# Altium schematic sheet Master_Rev4_½AA.SchDoc
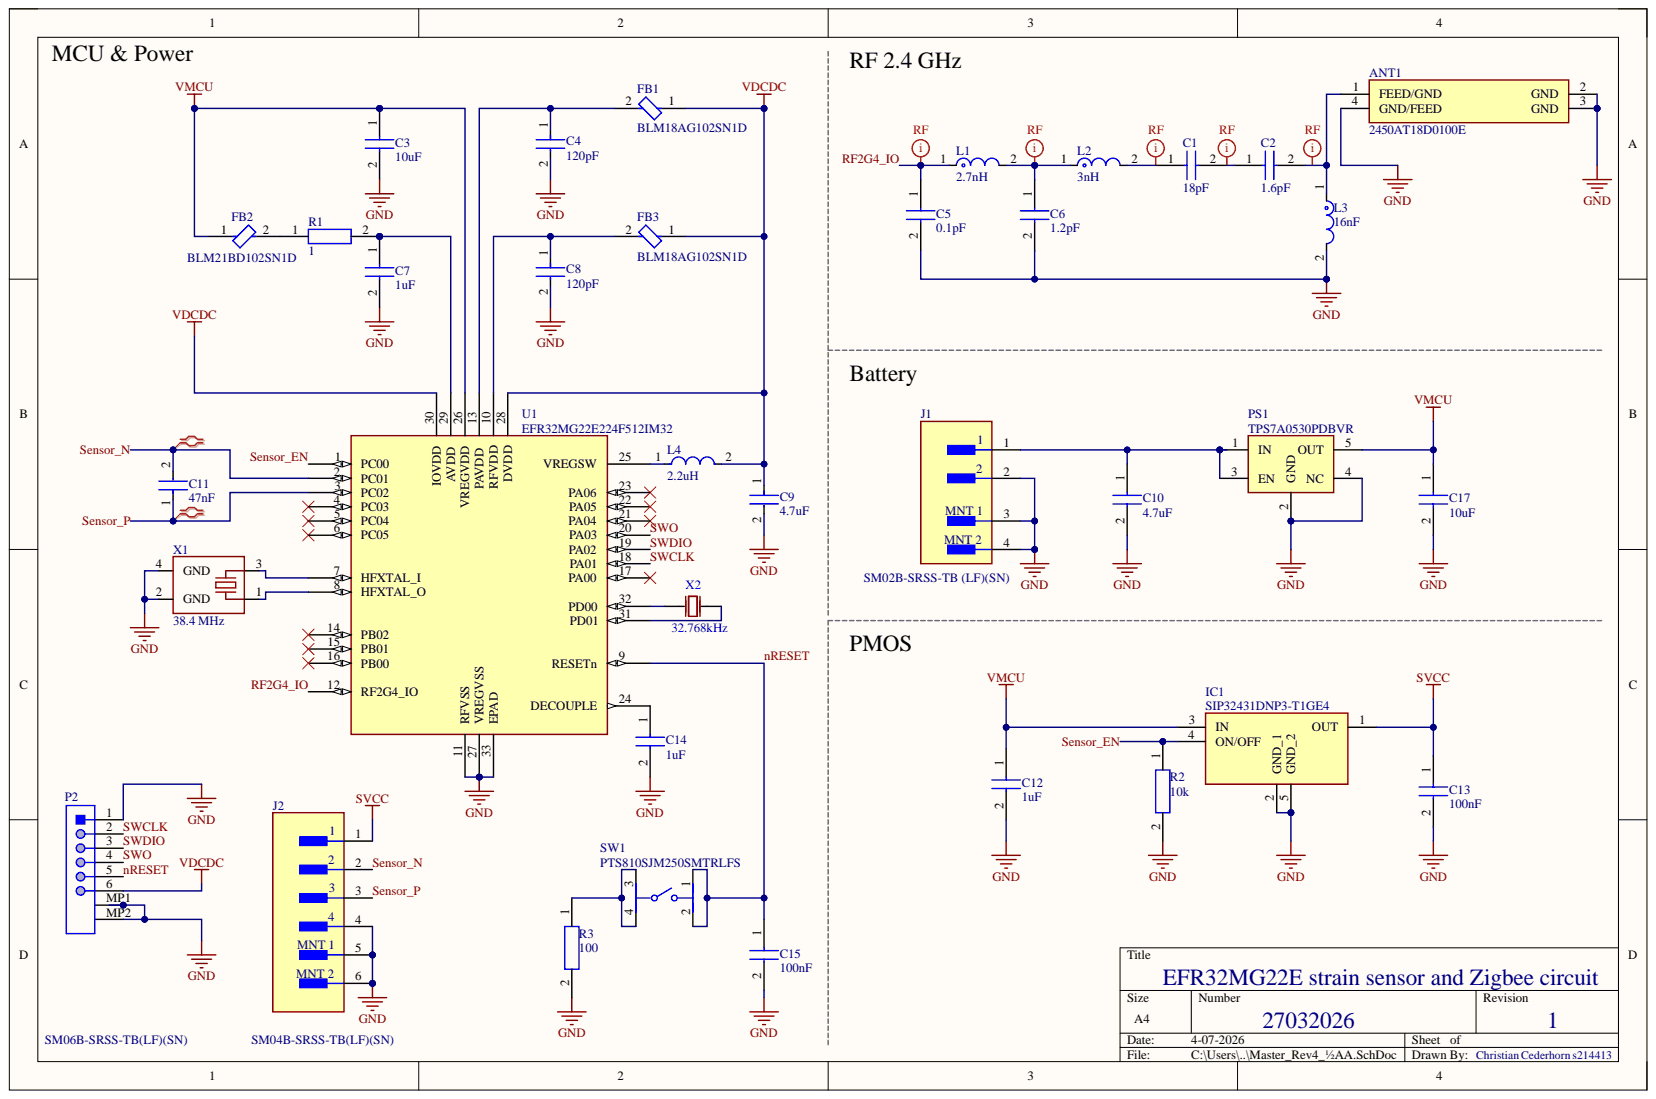
[diagram p0: Master_Rev4_½AA.SchDoc]

## Parts
ANT1  2450AT18D0100E  [FP-2450AT18D0100E-MFG]
C1  18pF  [FP-GJM033-0_03-IPC_A]
C2  1.6pF  [FP-GRM033-0_03-IPC_A]
C3  10uF  [CAPC1608X100N]
C4  120pF  [FP-GRM033-0_03-IPC_A]
C5  0.1pF  [FP-GRM033-0_03-IPC_A]
C6  1.2pF  [FP-GRM033-0_03-IPC_A]
C7  1uF  [CAPC1608X90X35ML15T15]
C8  120pF  [FP-GRM033-0_03-IPC_A]
C9  4.7uF  [FP-CL10-IPC_B]
C10  4.7uF  [FP-CL10-IPC_B]
C11  47nF  [FP-CC0201-BA-IPC_A]
C12  1uF  [CAPC1608X90X35ML15T15]
C13  100nF  [FP-GRM033-0_03-IPC_A]
C14  1uF  [CAPC0603X33N]
C15  100nF  [FP-GRM033-0_03-IPC_A]
C17  10uF  [CAPC1608X100N]
FB1  BLM18AG102SN1D  [FP-BLM18-0_15-t0_8-MFG]
FB2  BLM21BD102SN1D  [FP-BLM21-0_2-t0_85-e0_5-IPC_A]
FB3  BLM18AG102SN1D  [FP-BLM18-0_15-t0_8-MFG]
IC1  SIP32431DNP3-T1GE4  [SON50P160X120X60-5N-D]
J1  SM02B-SRSS-TB (LF)(SN)  [FP-SM02B-SRSS-TB_LF_SN-MFG]
J2  SM04B-SRSS-TB(LF)(SN)  [CONN_SM04B_JST]
L1  2.7nH  [FP-LQP03TG_02-IPC_A]
L2  3nH  [FP-LQP03TG_02-IPC_A]
L3  16nF  [FP-LQP03TG_02-IPC_A]
L4  2.2uH  [FP-LQM18PZ_FH-IPC_A]
P2  SM06B-SRSS-TB(LF)(SN)  [JST-SM06B-SRSS-TB_V]
PS1  TPS7A0530PDBVR  [SOT95P280X145-5N]
R1  1  [RESC1608X55N]
R2  10k  [RESC0603X28X15ML05T05]
R3  100  [RESC0603X28X15ML05T05]
SW1  PTS810SJM250SMTRLFS  [FP-PTS810SJM250SMTRLFS-MFG]
U1  EFR32MG22E224F512IM32  [QFN32_4X4]
X1  38.4 MHz  [12.85730]
X2  32.768kHz  [DFN100P160X50-2N]

## Nets
GND: ANT1.2(GND) ANT1.3(GND) ANT1.4(GND/FEED) C3.2 C4.2 C5.2 C6.2 C7.2 C8.2 C9.2 C10.2 C12.2 C13.2 C14.2 C15.2 C17.2 IC1.2(GND_1) IC1.5(GND_2) J1.2 J1.3 J1.4 J2.4 J2.5 J2.6 L3.2 P2.1 P2.MP1 P2.MP2 PS1.2(GND) PS1.4(NC) R2.2 R3.2 U1.11(RFVSS) U1.27(VREGVSS) U1.33(EPAD) X1.2(GND) X1.4(GND)
SVCC: C13.1 IC1.1(OUT) J2.1
VDCDC: C9.1 FB1.1 FB3.1 L4.2 P2.6 U1.28(DVDD) U1.30(IOVDD)
VMCU: C3.1 C12.1 C17.1 FB2.1 IC1.3(IN) PS1.5(OUT) U1.26(VREGVDD)
nRESET: C15.1 P2.5 SW1.1 SW1.2 U1.9(RESETn)
RF2G4_IO: C5.1 L1.1 U1.12(RF2G4_IO)
Sensor_EN: IC1.4(ON/OFF) R2.1 U1.1(PC00)
Sensor_N: C11.2 J2.2 U1.2(PC01)
Sensor_P: C11.1 J2.3 U1.3(PC02)
SWCLK: P2.2 U1.18(PA01)
SWDIO: P2.3 U1.19(PA02)
SWO: P2.4 U1.20(PA03)
NetANT1_1: ANT1.1(FEED/GND) C2.2 L3.1
NetC1_1: C1.1 L2.2
NetC1_2: C1.2 C2.1
NetC4_1: C4.1 FB1.2 U1.13(PAVDD)
NetC6_1: C6.1 L1.2 L2.1
NetC7_1: C7.1 R1.2 U1.29(AVDD)
NetC8_1: C8.1 FB3.2 U1.10(RFVDD)
NetC10_1: C10.1 J1.1 PS1.1(IN) PS1.3(EN)
NetC14_1: C14.1 U1.24(DECOUPLE)
NetFB2_2: FB2.2 R1.1
NetL4_1: L4.1 U1.25(VREGSW)
NetR3_1: R3.1 SW1.3 SW1.4
NetU1_7: U1.7(HFXTAL_I) X1.3
NetU1_8: U1.8(HFXTAL_O) X1.1
NetU1_31: U1.31(PD01) X2.2
NetU1_32: U1.32(PD00) X2.1

Not connected: U1 4(PC03) 5(PC04) 6(PC05) 14(PB02) 15(PB01) 16(PB00) 17(PA00) 21(PA04) 22(PA05) 23(PA06)

## Library data of the parts
ANT1 = CMP-2000-05543-2: RF ANT 2.4GHZ CHIP SOLDER SMD; Bandwidth: 100MHz; Frequency: 2.45GHz; Gain: -1dB; Impedance: 50R; Max Power Dissipation: 2W; Number of Bands: 1; Return Loss: -10dB; Case/Package: SMD/SMT; Component Kind: Standard; Height: 0.047inch; Length: 3.2mm; Library Name: Altium Content Vault; Mount: Surface Mount; Package Quantity: 3000; Packaging: Tape and Reel; Power Rating: 2W; Radiation Hardening: No; RoHS Compliant: Yes; Series: -; Termination: Solder; Width: 1.6mm
C1 = CMP-06035-025415-1: Ceramic Capacitor 18pF ±2% 25V C0G 0201 (0603 Metric) GJM0335C1E180GB01D; Capacitance: 18pF; Case Code (Imperial): 0201; Case Code (Metric): 0603; Case/Package: 0201; Component Kind: Standard; Dielectric: C0G; Height: 0.3mm; Lead Free: Lead Free; Length: 0.6mm; Max Operating Temperature: 125°C; Min Operating Temperature: -55°C; Package Quantity: 15000; Packaging: Tape and Reel; Resistor Type: Ceramic; Termination: SMD/SMT; Thickness: 300um; Tolerance: 2%; Voltage Rating (DC): 25V; Weight: 1.2E-05oz; Width: 0.3mm; Library Name: Master_Rev3_½AA.SCHLIB
C2 = CMP-2010-01519-2: Chip Multilayer Ceramic Capacitors for General Purpose, 0201, 1.6pF, C0G, 30ppm/°C, 0.1pF, 50V GRM0335C1H1R6BA01D; Capacitance: 1.6pF; Case Code (Imperial): 0201; Case Code (Metric): 0603; Case/Package: 0201; Component Kind: Standard; Dielectric: C0G; Height: 0.3mm; Length: 0.024inch; Max Operating Temperature: 125°C; Min Operating Temperature: -55°C; Mount: Surface Mount; Package Quantity: 15000; Packaging: Tape and Reel; Termination: SMD/SMT; Thickness: 0.013inch; Voltage Rating: 50V; Voltage Rating (DC): 50V; Width: 0.012inch; Library Name: Master_Rev3_½AA.SCHLIB
C3 C17 = CC0603MRX5R7BB106: Capacitor CC0603MRX5R7BB106; Manufacturer_Name: YAGEO; Arrow Part Number: CC0603MRX5R7BB106; Arrow Price/Stock: https://www.arrow.com/en/products/cc0603mrx5r7bb106/yageo?utm_currency=USD&region=europe; Component Kind: Standard; Datasheet Link: https://www.yageo.com/upload/media/product/app/datasheet/mlcc/upy-gphc_x5r_4v-to-50v.pdf; Height: 1mm; Manufacturer_Part_Number: CC0603MRX5R7BB106; Mouser Part Number: 603-CC603MRX5R7BB106; Mouser Price/Stock: https://www.mouser.co.uk/ProductDetail/YAGEO/CC0603MRX5R7BB106?qs=shS2SvXCj6KqRgvkLCck%252Bg%3D%3D
C4 C8 = CMP-2010-01502-2: Chip Multilayer Ceramic Capacitors for General Purpose, 0201, 120pF, C0G, 30ppm/°C, 5%, 50V GRM0335C1H121JA01D; Capacitance: 120pF; Case Code (Imperial): 0201; Case Code (Metric): 0603; Case/Package: 0201; Component Kind: Standard; Dielectric: C0G; Height: 0.3mm; Lead Free: Lead Free; Length: 0.024inch; Library Name: Altium Content Vault; Max Operating Temperature: 125°C; Min Operating Temperature: -55°C; Mount: Surface Mount; Package Quantity: 15000; Packaging: Tape and Reel; Termination: SMD/SMT; Thickness: 0.013inch; Tolerance: 5%; Voltage Rating: 50V; Voltage Rating (DC): 50V; Weight: 1.2E-05oz; Width: 0.3mm
C5 = CMP-2010-01634-2: Chip Multilayer Ceramic Capacitors for General Purpose, 0201, 0.10pF, C0G, 30ppm/°C, 0.05pF, 50V GRM0335C1HR10WA01D; Capacitance: 0.1pF; Case Code (Imperial): 0201; Case Code (Metric): 0603; Case/Package: 0201; Component Kind: Standard; Dielectric: C0G; Height: 0.3mm; Length: 0.024inch; Max Operating Temperature: 125°C; Min Operating Temperature: -55°C; Mount: Surface Mount; Package Quantity: 15000; Packaging: Tape and Reel; Termination: SMD/SMT; Thickness: 0.013inch; Voltage Rating: 50V; Voltage Rating (DC): 50V; Width: 0.012inch; Library Name: Master_Rev3_½AA.SCHLIB
C6 = CMP-2010-01513-3: Chip Multilayer Ceramic Capacitors for General Purpose, 0201, 1.2pF, C0G, 30ppm/°C, 0.1pF, 50V GRM0335C1H1R2BA01D; Capacitance: 1.2pF; Case Code (Imperial): 0201; Case Code (Metric): 0603; Case/Package: 0201; Component Kind: Standard; Depth: 0.3mm; Dielectric: C0G; Height: 0.3mm; Length: 0.6mm; Max Operating Temperature: 125°C; Min Operating Temperature: -55°C; Mount: Surface Mount; Package Quantity: 15000; Packaging: Tape and Reel; Radiation Hardening: No; Termination: SMD/SMT; Thickness: 0.013inch; Voltage Rating: 50V; Voltage Rating (DC): 50V; Width: 0.012inch; Library Name: Master_Rev3_½AA.SCHLIB
C7 C12 = CMP-2006-02271-1: C0603C105M8PACTU; Manufacturer: Kemet; Component Kind: Standard; Part Number: C0603C105M8PACTU; Library Name: Master_Rev3_½AA.SCHLIB
C9 C10 = CMP-2000-06096-2: CAP CER 4.7UF 6.3V X7R 0603 CL10B475KQ8NQNC; Manufacturer: Samsung; Material: Ceramic; Temperature Coefficient: 15%; Tolerance: 10%; Automotive: No; Capacitance: 4.7uF; Category: Cap; Component Kind: Standard; ComponentLink2Description: Datasheet; ComponentLink2URL: http://www.samsungsem.com/kr/support/product-search/mlcc/__icsFiles/afieldfile/2018/07/24/CL10B475KQ8NQNC.pdf; Device Class L1: Passive Components; Device Class L2: Capacitors; Device Class L3: Ceramic Capacitors; Digikey Description: CAP CER 4.7UF 6.3V X7R 0603; Height: 0.95mm; Ipc Land Pattern Name: CAPC16080X80; Lead Free: Yes; Manufacturer Part Number: CL10B475KQ8NQNC; Mouser Description: C Series 0402 4.7 uF 6.3 V ±20 % Tolerance X5R SMT Multilayer Ceramic Capacitor; Octopart: https://octopart.com/cl10b475kq8nqnc-samsung-24957976; Package: CL10; RoHS: TRUE; Temperature: -55°C to +125°C; Temperature Characteristic: X7R; Temperature Range High: +125°C; Temperature Range Low: -55°C; Value: 4.7uF; Voltage Rating: 6.3V
  C9: Library Name: Altium Content Vault
  C10: Library Name: Master_Rev3_½AA.SCHLIB
C11 = CMP-03422-001035-1: CC0201 47 nF X5R 10.00% 10 V CC0201KRX5R6BB473; Manufacturer: Yageo; Material: Ceramic; Temperature Coefficient: 15%; Tolerance: 0.1; Voltage Rating: 10 V; Automotive: No; Capacitance: 47 nF; Category: Cap; Component Kind: Standard; ComponentLink2Description: Datasheet; ComponentLink2URL: http://www.yageo.com/documents/recent/UPY-GPHC_X5R_4V-to-50V_25.pdf; Device Class L1: Passive Components; Device Class L2: Capacitors; Device Class L3: Ceramic Capacitors; Footprint Url: http://www.yageo.com/exep/pages/download/literatures/UPY-C_GEN_24.pdf; Height: 0.33mm; Ipc Land Pattern Name: CAPC6030X30; Lead Free: Yes; Library Name: Altium Content Vault; Manufacturer Part Number: CC0201KRX5R6BB473; Octopart: https://octopart.com/cc0201krx5r6bb473-yageo-87694332; Package: CC0201-BA; RoHS: TRUE; Temperature: -55°C to +85°C; Temperature Characteristic: X5R; Temperature Range High: +85°C; Temperature Range Low: -55°C; Value: 47 nF
C13 C15 = CMP-06035-039275-1: Chip Multilayer Ceramic Capacitors for General Purpose, 0201, 0.10uF, X5R, 15%, 10%, 16V GRM033R61C104KE14D; Capacitance: 100nF; Component Kind: Standard; Dielectric: X5R; Length: 600um; Thickness: 300um; Tolerance: 10%; Voltage Rating (DC): 16V; Width: 300um; Library Name: Master_Rev3_½AA.SCHLIB
C14 = 0201YD105MAT2A: Capacitor 0201YD105MAT2A; Manufacturer_Name: Kyocera AVX; Component Kind: Standard; Datasheet Link: http://www.mouser.com/catalog/supplier/library/pdf/AVXSurfaceMountCeramic.pdf; Height: 0.33mm; Manufacturer_Part_Number: 0201YD105MAT2A; Mouser Part Number: 581-0201YD105MAT2A; Mouser Price/Stock: https://www.mouser.co.uk/ProductDetail/KYOCERA-AVX/0201YD105MAT2A?qs=%252BdQmOuGyFcGQHOyb4lLyTA%3D%3D
FB1 FB3 = CMP-0686-00457-3: Chip Ferrite Bead, 0603, 1000Ω @ 100MHz, 0.5Ω, 25%, 450mA; Current Rating: 400mA; DC Resistance (DCR): 500mR; DC Resistance-Max: 700mR; Impedance: 1kR; Material: Steel; Number of Circuits: 1; Shielding: Unshielded; Case Code (Imperial): 0603; Case Code (Metric): 1608; Case/Package: 0603; Component Kind: Standard; Core Material: Ferrite; DC Current: 400mA; Depth: 0.8mm; Frequency: 100MHz; Height: 0.8mm; Lead Free: Lead Free; Length: 1.6mm; Max Current Rating: 400mA; Max DC Current: 400mA; Max Operating Temperature: 125°C; Military Standard: Not; Min Operating Temperature: -55°C; Number of Lines: 1; Number of Pins: 2; Package Quantity: 1; Packaging: Tape and Reel; Radiation Hardening: No; REACH SVHC: No SVHC; Resistance: 500mR; Series: BLM18A; Termination: SMD/SMT; Test Frequency: 100MHz; Tolerance: 25%; Width: 0.8mm; Library Name: Master_Rev3_½AA.SCHLIB
FB2 = CMP-0686-00674-3: Chip Ferrite Bead, 0805, 1000Ω @ 100MHz, 0.4Ω, 25%, 250mA; Current Rating: 200mA; DC Resistance (DCR): 400mR; Impedance: 1kR; Material: Steel; Number of Circuits: 1; Shielding: Unshielded; Case Code (Imperial): 0805; Case Code (Metric): 2012; Case/Package: 0805; Component Kind: Standard; Core Material: Ferrite; DC Current: 200mA; DC Resistance-Max: 400mR; Depth: 1.25mm; Frequency: 100MHz; Height: 0.85mm; Lead Free: Lead Free; Length: 2mm; Max Current Rating: 250mA; Max DC Current: 200mA; Max Operating Temperature: 125°C; Military Standard: Not; Min Operating Temperature: -55°C; Number of Lines: 1; Number of Pins: 2; Package Quantity: 1; Packaging: Tape and Reel; Radiation Hardening: No; REACH SVHC: No SVHC; Resistance: 400mR; RoHS Compliant: Yes; Series: BLM21B; Termination: SMD/SMT; Test Frequency: 100MHz; Tolerance: 25%; Weight: 0.000194oz; Width: 1.25mm; Library Name: Master_Rev3_½AA.SCHLIB
IC1 = SIP32431DNP3-T1GE4: Integrated Circuit; Manufacturer_Name: Vishay; Arrow Part Number: SIP32431DNP3-T1GE4; Arrow Price/Stock: https://www.arrow.com/en/products/sip32431dnp3-t1ge4/vishay?utm_currency=USD&region=nac; Component Kind: Standard; Datasheet Link: https://www.vishay.com/docs/66597/sip32431.pdf; Height: 0.6mm; Library Name: Master.SchLib; Manufacturer_Part_Number: SIP32431DNP3-T1GE4; Mouser Part Number: 781-SIP32431DNP3-GE4; Mouser Price/Stock: https://www.mouser.co.uk/ProductDetail/Vishay-Semiconductors/SIP32431DNP3-T1GE4?qs=FfLXECYddQQ0YorCqBSrEw%3D%3D
J1 = CMP-17439-000368-1: CONN HEADER SMD R/A 2POS 1MM; Contact Resistance: 40mR; Current Rating: 700mA; Insulation Resistance: 100MR; Max Current Rating: 1A; Max Voltage Rating (AC): 50V; Number of Contacts: 2; Color: Natural; Component Kind: Standard; Connector Type: Header; Contact Finish - Mating: TIN; Contact Material: Copper Alloy; Contact Plating: Tin; Contact Shape: Square; Contact Type: Male Pin; Depth: 4.95mm; Fastening Type: Pull; Flammability Rating: UL94 V-0; Housing Color: Beige; Housing Material: Polyamide; Insulation Color: Natural; Insulation Material: Nylon; Lead Free: Lead Free; Lead Pitch: 1mm; Length: 4mm; Library Name: Altium Content Vault; Material: Polyamide; Max Operating Temperature: 85°C; Min Operating Temperature: -25°C; Mount: Surface Mount; Number of Positions: 2; Number of Rows: 1; Orientation: Right Angle; Packaging: Tape and Reel; Pitch: 1mm; REACH SVHC: No SVHC; Series: SR; Termination: Solder; Voltage Rating: 50V; Voltage Rating (AC): 50V
J2 = SM04B-SRSS-TBLFSN: Description; Manufacturer_Name: JST; Component Kind: Standard; Library Name: Master.SchLib; Manufacturer_Part_Number: SM04B-SRSS-TB(LF)(SN); RefDes: RefDes; Type: Type; Copyright: Copyright (C) 2025 Ultra Librarian. All rights reserved.
L1 = CMP-06042-013213-1: Film type RF Inductor 2.7nH ±0.1nH 500mA 0.2Ω 0201 (0603) LQP03TN2N7B02D; Core Material: Non-Magnetic; Current: 350mA; DC Resistance (DCR): 200mR; Q Factor: 14; Self Resonant Frequency: 6GHz; Shielding: Unshielded; Test Frequency: 500MHz; Tolerance: 3%; Case Code (Imperial): 0201; Case Code (Metric): 0603; Case/Package: 0201; Component Kind: Standard; Current Rating: 500mA; DC Current: 500mA; Depth: 0.33mm; Height: 0.3mm; Height - Seated (Max): 0.013inch; Inductance: 2.7nH; Lead Free: Lead Free; Length: 0.024inch; Max DC Current: 500mA; Max Operating Temperature: 125°C; Military Standard: Not; Min Operating Temperature: -55°C; Mount: Surface Mount; Package Quantity: 15000; Packaging: Tape and Reel; Radiation Hardening: No; REACH SVHC: No SVHC; Resistor Type: Thin Film; Termination: SMD/SMT; Width: 0.3mm; Library Name: Master_Rev3_½AA.SCHLIB
L2 = CMP-06042-015477-1: Film type RF Inductor 3nH ±0.1nH 450mA 0.25Ω 0201 (0603) LQP03TN3N0B02D; Core Material: Non-Magnetic; Current: 300mA; DC Resistance (DCR): 250mR; Q Factor: 14; Self Resonant Frequency: 9.5GHz; Shielding: Unshielded; Test Frequency: 500MHz; Tolerance: 3%; Case Code (Imperial): 0201; Case Code (Metric): 0603; Case/Package: 0201; Component Kind: Standard; Current Rating: 450mA; DC Current: 450mA; Depth: 0.33mm; Height: 0.3mm; Height - Seated (Max): 0.013inch; Inductance: 3nH; Length: 0.6mm; Max DC Current: 450mA; Max Operating Temperature: 125°C; Military Standard: Not; Min Operating Temperature: -55°C; Mount: Surface Mount; Package Quantity: 15000; Packaging: Tape and Reel; Radiation Hardening: No; REACH SVHC: No SVHC; Resistor Type: Thin Film; Series: LQP; Technology: THIN FILM; Termination: SMD/SMT; Width: 0.012inch; Library Name: Master_Rev3_½AA.SCHLIB
L3 = CMP-06042-007780-1: Film type RF Inductor 16nH ±5% 200mA 0.95Ω 0201 (0603) LQP03TN16NJ02D; Core Material: Non-Magnetic; DC Resistance (DCR): 950mR; Self Resonant Frequency: 2.2GHz; Shielding: Unshielded; Test Frequency: 500MHz; Case/Package: 0201; Component Kind: Standard; Current Rating: 200mA; DC Current: 200mA; Depth: 0.33mm; Height - Seated (Max): 0.013inch; Inductance: 16nH; Length: 0.024inch; Max Operating Temperature: 125°C; Military Standard: Not; Min Operating Temperature: -55°C; Mount: Surface Mount; Packaging: Tape and Reel; Resistor Type: Thin Film; Tolerance: 5%; Width: 0.012inch; Library Name: Master_Rev3_½AA.SCHLIB
L4 = CMP-06042-017061-1: FIXED IND 2.2UH 700MA 470MOHM SM LQM18PZ2R2MFHD; Core Material: Ferrite; DC Resistance (DCR): 470mR; Saturation Current: 300mA; Self Resonant Frequency: 80MHz; Shielding: Shielded; Test Frequency: 1MHz; Tolerance: 20%; Case Code (Imperial): 1008; Case Code (Metric): 2520; Case/Package: 0603; Component Kind: Standard; Current Rating: 700mA; Inductance: 2.2uH; Library Name: Altium Content Vault; Max Operating Temperature: 125°C; Min Operating Temperature: -55°C; Mount: Surface Mount; Packaging: Cut Tape; Ratings: AEC-Q200; Resistor Type: Thin Film; RoHS Compliant: Yes
P2 = CMP-2000-07080-1: Male Header, Pitch 1 mm, 1 x 6 Position, Height 2.95 mm, -25 to 85 degC, RoHS, Tape and Reel; Manufacturer: JST; No of Positions: 6; Pitch: 1mm; Style/Orientation: RightAngleHeader/Plug; Component Kind: Standard; Datasheet URL: https://datasheet.ciiva.com/26894/getdatasheetpartid-708885-26894671.pdf; Datasheet Version: 11/2010; Library Name: Altium Content Vault; Manufacturer URL: http://www.jst.com/home2.html; Mounting Technology: SurfaceMount; Package Description: Male Header, Pitch 1 mm, 1 X 6 Position, Height 2.9 mm; Package Reference: SM06B-SRSS-TB; Termination: Solder; Type: ShroudedHeader
PS1 = TPS7A0530PDBVR: Power Supply; Manufacturer_Name: Texas Instruments; Arrow Part Number: TPS7A0530PDBVR; Arrow Price/Stock: https://www.arrow.com/en/products/tps7a0530pdbvr/texas-instruments?region=nac; Component Kind: Standard; Datasheet Link: http://www.ti.com/lit/gpn/tps7a05; Height: 1.45mm; Library Name: Master.SchLib; Manufacturer_Part_Number: TPS7A0530PDBVR; Mouser Part Number: 595-TPS7A0530PDBVR; Mouser Price/Stock: https://www.mouser.co.uk/ProductDetail/Texas-Instruments/TPS7A0530PDBVR?qs=byeeYqUIh0OXf2T23vUe3w%3D%3D
R1 = MCT0603MC1008FP500: Resistor MCT0603MC1008FP500; Manufacturer_Name: Vishay; Component Kind: Standard; Datasheet Link: http://www.vishay.com/docs/28705/mcx0x0xpro.pdf; Height: 0.55mm; Library Name: Master.SchLib; Manufacturer_Part_Number: MCT0603MC1008FP500
R2 = CMP-2004-00016-1: CRCW020110K0FNED; Manufacturer: Vishay Dale; Component Kind: Standard; Part Number: CRCW020110K0FNED; Library Name: Master_Rev3_½AA.SCHLIB
R3 = CMP-2004-00006-1: CRCW0201100RFNED; Manufacturer: Vishay; Component Kind: Standard; Part Number: CRCW0201100RFNED; Library Name: Master_Rev3_½AA.SCHLIB
SW1 = CMP-10040-000001-2: SWITCH TACTILE SPST-NO 0.05A 16V; Actuator Type: Standard; Current Rating: 50mA; Illumination Type: Non-Illuminated; Mechanical Life: 150000Cycles; Operating Force: 160gf; Switch Function: Off-Mom; Switch Travel: 0.15mm; Throw Configuration: SPST; Case/Package: SMD/SMT; Color: Black; Component Kind: Standard; Contact Plating: Silver; Depth: 4.6mm; Lead Free: Lead Free; Length: 3.2mm; Library Name: Altium Content Vault; Max Current Rating: 50mA; Max Operating Temperature: 85°C; Min Operating Temperature: -40°C; Mount: Surface Mount; Orientation: Straight; Package Quantity: 2900; Packaging: Tape and Reel; RoHS Compliant: Yes; Termination: J-Bend; Voltage Rating (DC): 16V
U1 = EFR32MG22E224F512IM32: RF System on a Chip - SoC Mighty Gecko, QFN32, 2.4G, 6dB, Mesh, 512kB, 32kB(RAM), 18 GPIO; Manufacturer_Name: Silicon Labs; Component Kind: Standard; ComponentLink1Description: New link; ComponentLink1URL: https://www.mouser.dk/ProductDetail/Silicon-Labs/EFR32MG22E224F512IM32-C?qs=i8QVZAFTkqTcSBjAeVnmxQ%3D%3D; Library Name: Master.SchLib; Manufacturer_Part_Number: EFR32MG22E224F512IM32; RefDes: RefDes; Type: Type; Copyright: Copyright (C) 2026 Ultra Librarian. All rights reserved.
X1 = 12.85730: 38.4 MHz ±10 ppm crystals, 10 pF, 40 Ω, 4‑SMD, no lead; Manufacturer_Name: Geyer Electronic America, Inc.; Component Kind: Standard; Library Name: Master.SchLib; Manufacturer_Part_Number: 12.85730
X2 = DST1610A-32.768KHZ: CRYSTAL 32.7680KHZ 12.5PF SMD.; Manufacturer_Name: KDS; Component Kind: Standard; ComponentLink1Description: Datasheet; ComponentLink1URL: https://www.codico.com/en/mpattachment/file/download/id/1055/; Height: 0.5mm; Library Name: Master.SchLib; Manufacturer_Part_Number: DST1610A
